# Altium schematic sheet 200PinConnector.SchDoc
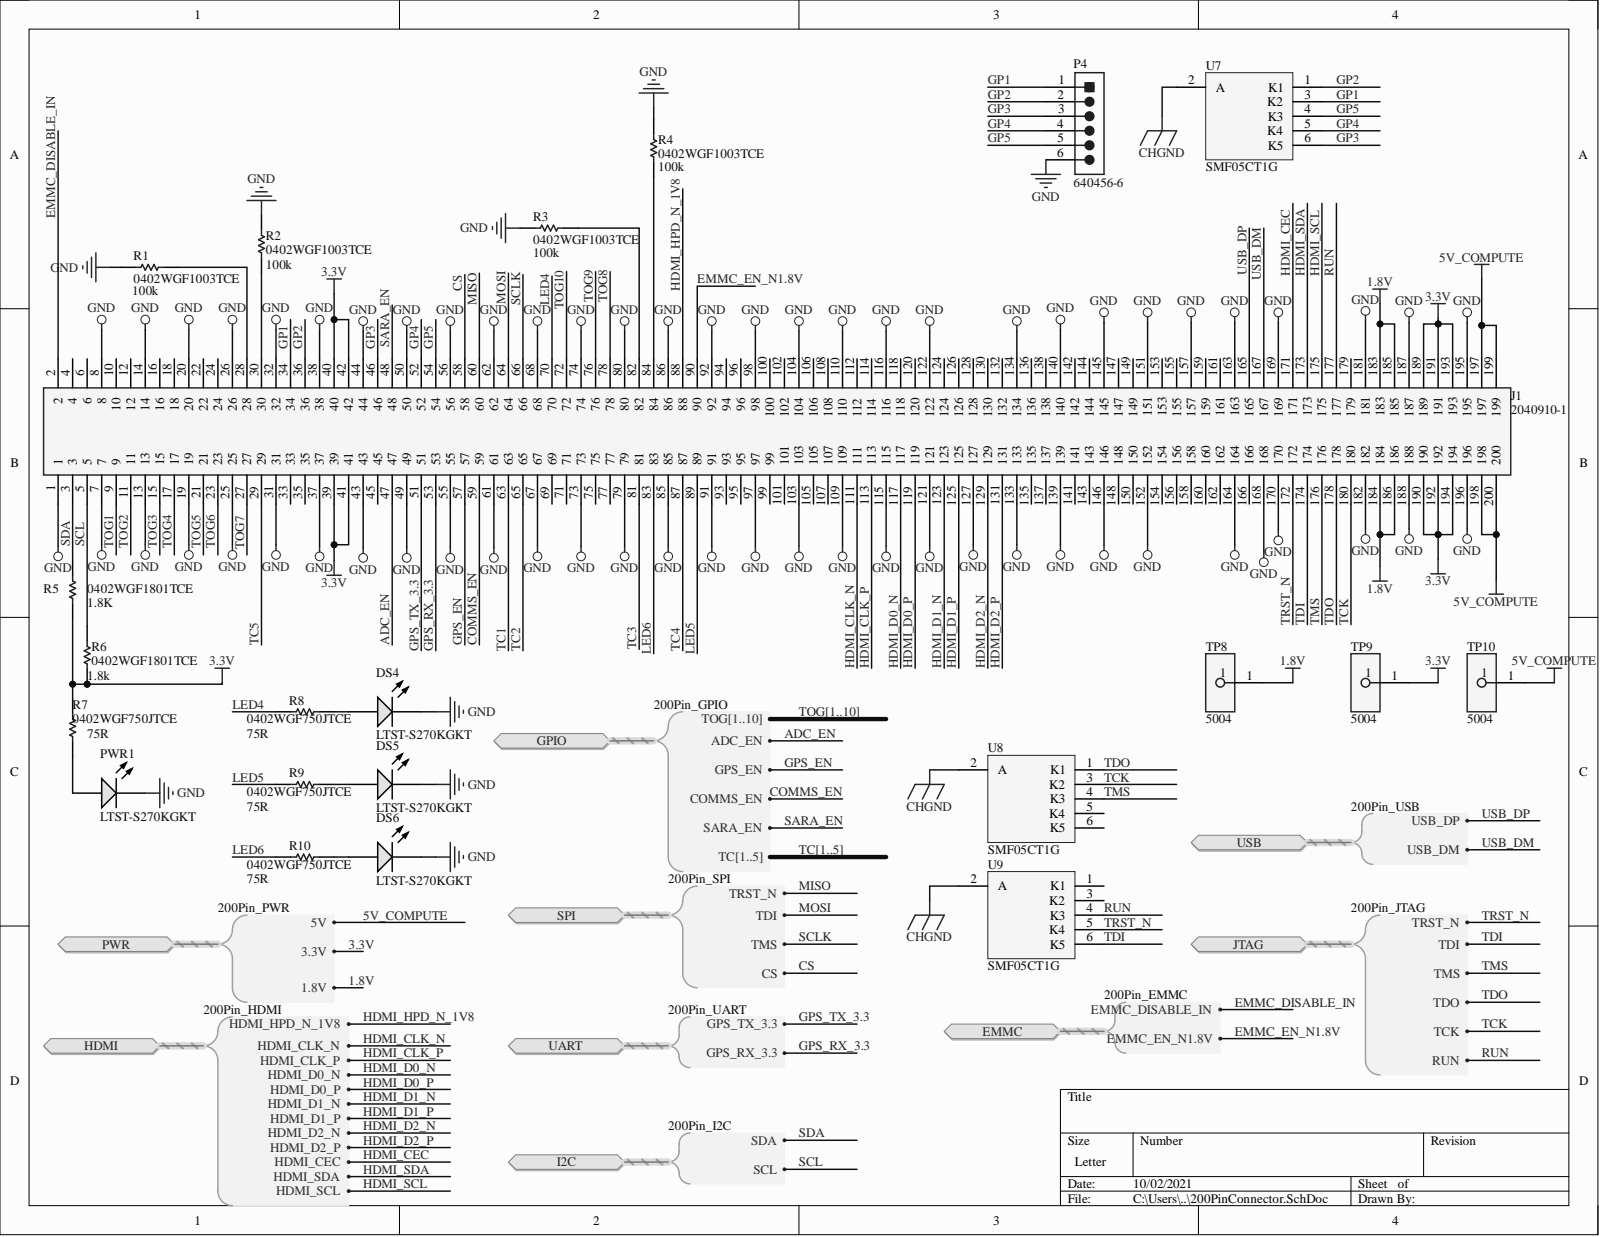
[diagram p0: 200PinConnector.SchDoc]

## Parts
DS4  LTST-S270KGKT  [AVAG-HSMX-C190_V]
DS5  LTST-S270KGKT  [AVAG-HSMX-C190_V]
DS6  LTST-S270KGKT  [AVAG-HSMX-C190_V]
J1  2040910-1  [20409101]
P4  640456-6  [TECO-640456-6_V]
PWR1  LTST-S270KGKT  [AVAG-HSMX-C190_V]
R1  0402WGF1003TCE  [0402]
R2  0402WGF1003TCE  [0402]
R3  0402WGF1003TCE  [0402]
R4  0402WGF1003TCE  [0402]
R5  0402WGF1801TCE  value 1.8K  [0402]
R6  0402WGF1801TCE  [0402]
R7  0402WGF750JTCE  [RESC1005X40X25ML05T10]
R8  0402WGF750JTCE  [RESC1005X40X25ML05T10]
R9  0402WGF750JTCE  [RESC1005X40X25ML05T10]
R10  0402WGF750JTCE  [RESC1005X40X25ML05T10]
TP8  5004  [FP-5004-MFG]
TP9  5004  [FP-5004-MFG]
TP10  5004  [FP-5004-MFG]
U7  SMF05CT1G  [ONSC-SC70-6-419B-02_W_V]
U8  SMF05CT1G  [ONSC-SC70-6-419B-02_W_V]
U9  SMF05CT1G  [ONSC-SC70-6-419B-02_W_V]

## Ports
EMMC, EMMC.EMMC_DISABLE_IN, EMMC.EMMC_EN_N1.8V, GPIO, GPIO.ADC_EN, GPIO.COMMS_EN, GPIO.GPS_EN, GPIO.SARA_EN, GPIO.TC1, GPIO.TC2, GPIO.TC3, GPIO.TC4, GPIO.TC5, GPIO.TC[1..5], GPIO.TOG1, GPIO.TOG2, GPIO.TOG3, GPIO.TOG4, GPIO.TOG5, GPIO.TOG6, GPIO.TOG7, GPIO.TOG8, GPIO.TOG9, GPIO.TOG10, GPIO.TOG[1..10], HDMI, HDMI.HDMI_CEC, HDMI.HDMI_CLK_N, HDMI.HDMI_CLK_P, HDMI.HDMI_D0_N, HDMI.HDMI_D0_P, HDMI.HDMI_D1_N, HDMI.HDMI_D1_P, HDMI.HDMI_D2_N, HDMI.HDMI_D2_P, HDMI.HDMI_HPD_N_1V8, HDMI.HDMI_SCL, HDMI.HDMI_SDA, I2C, I2C.SCL, I2C.SDA, JTAG, JTAG.RUN, JTAG.TCK, JTAG.TDI, JTAG.TDO, JTAG.TMS, JTAG.TRST_N, PWR, PWR.1.8V, PWR.3.3V, PWR.5V, SPI, SPI.CS, SPI.TDI, SPI.TMS, SPI.TRST_N, UART, UART.GPS_RX_3.3, UART.GPS_TX_3.3, USB, USB.USB_DM, USB.USB_DP

## Nets
CHGND: U7.2(A) U8.2(A) U9.2(A)
GND: DS4.2 DS5.2 DS6.2 J1.1 J1.7 J1.8 J1.13 J1.14 J1.19 J1.20 J1.25 J1.26 J1.31 J1.32 J1.37 J1.38 J1.43 J1.44 J1.49 J1.50 J1.55 J1.56 J1.61 J1.62 J1.67 J1.68 J1.73 J1.74 J1.79 J1.80 J1.85 J1.86 J1.91 J1.92 J1.97 J1.98 J1.103 J1.104 J1.109 J1.110 J1.115 J1.116 J1.121 J1.122 J1.127 J1.133 J1.134 J1.139 J1.140 J1.145 J1.146 J1.151 J1.152 J1.157 J1.163 J1.164 J1.168 J1.169 J1.170 J1.181 J1.182 J1.187 J1.188 J1.195 J1.196 P4.6 PWR1.2 R1.2 R2.2 R3.2 R4.2
1.8V: J1.183 J1.184 J1.185 J1.186 TP8.1 ; port PWR
3.3V: J1.39 J1.40 J1.41 J1.42 J1.189 J1.190 J1.191 J1.192 J1.193 J1.194 R5.1 R6.1 R7.1 TP9.1 ; port PWR
5V_COMPUTE: J1.197 J1.198 J1.199 J1.200 TP10.1 ; port PWR
ADC_EN: J1.47 ; port GPIO
COMMS_EN: J1.59 ; port GPIO
CS: J1.58 ; port SPI
EMMC_DISABLE_IN: J1.2 ; port EMMC
EMMC_EN_N1.8V: J1.90 ; port EMMC
GP1: J1.34 P4.1 U7.3(K2)
GP2: J1.36 P4.2 U7.1(K1)
GP3: J1.46 P4.3 U7.6(K5)
GP4: J1.52 P4.4 U7.5(K4)
GP5: J1.54 P4.5 U7.4(K3)
GPS_EN: J1.57 ; port GPIO
GPS_RX_3.3: J1.53 ; port UART
GPS_TX_3.3: J1.51 ; port UART
HDMI_CEC: J1.171 ; port HDMI
HDMI_CLK_N: J1.111 ; port HDMI
HDMI_CLK_P: J1.113 ; port HDMI
HDMI_D0_N: J1.117 ; port HDMI
HDMI_D0_P: J1.119 ; port HDMI
HDMI_D1_N: J1.123 ; port HDMI
HDMI_D1_P: J1.125 ; port HDMI
HDMI_D2_N: J1.129 ; port HDMI
HDMI_D2_P: J1.131 ; port HDMI
HDMI_HPD_N_1V8: J1.88 ; port HDMI
HDMI_SCL: J1.175 ; port HDMI
HDMI_SDA: J1.173 ; port HDMI
LED4: J1.70 R8.2
LED5: J1.89 R9.2
LED6: J1.83 R10.2
MISO: J1.60 ; port SPI
MOSI: J1.64 ; port SPI
RUN: J1.177 U9.4(K3) ; port JTAG
SARA_EN: J1.48 ; port GPIO
SCL: J1.5 R6.2 ; port I2C
SCLK: J1.66 ; port SPI
SDA: J1.3 R5.2 ; port I2C
TC1: J1.63 ; port GPIO  [also labelled TC]
TC2: J1.65 ; port GPIO  [also labelled TC]
TC3: J1.81 ; port GPIO  [also labelled TC]
TC4: J1.87 ; port GPIO  [also labelled TC]
TC5: J1.29 ; port GPIO  [also labelled TC]
TCK: J1.180 U8.3(K2) ; port JTAG
TDI: J1.174 U9.6(K5) ; port JTAG
TDO: J1.178 U8.1(K1) ; port JTAG
TMS: J1.176 U8.4(K3) ; port JTAG
TOG1: J1.9 ; port GPIO  [also labelled TOG]
TOG2: J1.11 ; port GPIO  [also labelled TOG]
TOG3: J1.15 ; port GPIO  [also labelled TOG]
TOG4: J1.17 ; port GPIO  [also labelled TOG]
TOG5: J1.21 ; port GPIO  [also labelled TOG]
TOG6: J1.23 ; port GPIO  [also labelled TOG]
TOG7: J1.27 ; port GPIO  [also labelled TOG]
TOG8: J1.78 ; port GPIO  [also labelled TOG]
TOG9: J1.76 ; port GPIO  [also labelled TOG]
TOG10: J1.72 ; port GPIO  [also labelled TOG]
TRST_N: J1.172 U9.5(K4) ; port JTAG
USB_DM: J1.167 ; port USB
USB_DP: J1.165 ; port USB
NetDS4_1: DS4.1 R8.1
NetDS5_1: DS5.1 R9.1
NetDS6_1: DS6.1 R10.1
NetJ1_28: J1.28 R1.1
NetJ1_30: J1.30 R2.1
NetJ1_82: J1.82 R3.1
NetJ1_84: J1.84 R4.1
NetPWR1_1: PWR1.1 R7.2

Not connected: J1 4 6 10 12 16 18 22 24 33 35 45 69 71 75 77 93 94 95 96 99 100 101 102 105 106 107 108 112 114 118 120 124 126 128 130 132 135 136 137 138 141 142 143 144 147 148 149 150 153 154 155 156 158 159 160 161 162 166 179; U8 5(K4) 6(K5); U9 1(K1) 3(K2)

## Library data of the parts
DS4-DS6 PWR1 = CMP-1744-00003-1: LED Uni-Color Yellow, 65 mW, 20 mA, -40 to 85 degC, 2-Pin SMD, RoHS, Tape and Reel; Case\Package: 0603; Power Rating: 100 mW; Resistance: 390 Ohm; Tolerance: 1%; Voltage Rating: 50 V; Case Code (Imperial): 0603; Case Code (Metric): 1608; Component Kind: Standard; ComponentLink1Description: Manufacturer URL; ComponentLink1URL: http://www.avagotech.com/; ComponentLink2Description: Datasheet; ComponentLink2URL: http://docs.avagotech.com/docs/AV02-0551EN; ComponentLink3Description: Package Specification; ComponentLink3URL: http://www.fairchildsemi.com/dwg/MA/MA03D.pdf; DatasheetVersion: Mar-12; Height: 0.45 mm; Length: 1.6 mm; Library Name: Altium Content Vault; Max Operating Temperature: 155 degC; Min Operating Temperature: -55 degC; Mount: Surface Mount; Package Quantity: 5000; PackageDescription: 2-Pin Surface Mount Device, Body 1.6 x 0.8 mm, Height 0.9 mm; PackageReference: HSMX-C190; Packaging: Tape and Reel; Pins: 2; REACH SVHC: No SVHC; RoHSCompliant: true; Voltage Rating (DC): 50 V; Width: 0.8 mm
J1 = 2040910-1: 200 Pin Connector; Manufacturer_Name: TE Connectivity; Arrow Part Number: 2040910-1; Arrow Price/Stock: https://www.arrow.com/en/products/2040910-1/te-connectivity; Component Kind: Standard; Datasheet Link: https://www.arrow.com/en/products/2040910-1/te-connectivity; Height: 15mm; Manufacturer_Part_Number: 2040910-1; Mouser Part Number: 571-2040910-1; Mouser Price/Stock: https://www.mouser.co.uk/ProductDetail/TE-Connectivity/2040910-1?qs=kAqyOuzYGe9tF8FZbDgYRA%3D%3D
P4 = CMP-2000-05661-1: Male Header, Pitch 2.54 mm, 1 x 6 Position, Height 10.03 mm, Tail Length 3.56 mm, -55 to 105 degC, Bulk; Manufacturer: TE Connectivity; Agency Approvals: CSA,UL; Angle: Straight; Brand/Series: MTA-100Series; Color, Housing: White; Connector Type: MTA-100; Contact Centerline Spacing: 0.100    in.; Contact Termination Area Plating: Tin; Current, Rating: 5    A; Material, Contact: CopperAlloy; Material, Housing: Polyester; Mount Angle: Vertical; Mounting Hole Size: 0.04    "; Number of Contacts: 6; Pin Spacing: 0.1    "; Primary Type: InterconnectSystem; Special Features: Pinstrip; Standards: RoHSCompliant,ELVCompliant,UL94V-0; Temperature, Operating: -55to+105    °C; Voltage, Rating: 250    V; Color: White; Component Kind: Standard; Contact Mating Area Plating: Tin; Contact Plating: Tin; Contact Shape: Square; Datasheet URL: https://datasheet.ciiva.com/25618/0900766b80eb7fdd-25618163.pdf; Datasheet Version: Rev. W, 05/2005; Flammability Rating: UL94V-0; Length, Overall: 0.6    "; Length, Pin: 0.14    "; Length, Terminal Post: 0.14    in.; Library Name: Altium Content Vault; Manufacturer URL: http://www.te.com/; Mounting Technology: ThroughHole; Mounting Type: PCMount; Number of Positions: 6; Number of Rows: 1; Package Description: Male Header, Pitch 2.54 mm, 1 x 6 Position, Height 10.03 mm, Tail Length 3.56 mm; Package Reference: 640456-6; Size, Post: 0.025    in.; Termination: Solder; Type: PCBPlug
R1-R4 = Res1: 100k 0402; Component Kind: Standard; LatestRevisionDate: 17-Jul-2002; LatestRevisionNote: Re-released for DXP Platform.; Library Name: Miscellaneous Devices.IntLib; PackageDescription: Axial Device, Thru-Hole; 2 Leads; 0.3 in Pin Spacing; PackageReference: AXIAL-0.3; Published: 8-Jun-2000; Signal Integrity: Res; Simulation: RESISTOR; Publisher: Altium Limited
  R1: Case Code (Imperial): 0402
R5 R6 = Res1: 1.8k 0402; Component Kind: Standard; LatestRevisionDate: 17-Jul-2002; LatestRevisionNote: Re-released for DXP Platform.; Library Name: Miscellaneous Devices.IntLib; PackageDescription: Axial Device, Thru-Hole; 2 Leads; 0.3 in Pin Spacing; PackageReference: AXIAL-0.3; Published: 8-Jun-2000; Signal Integrity: Res; Simulation: RESISTOR; Publisher: Altium Limited
R7-R10 = CMP-2002-07344-1: 75R 0402; Manufacturer: Yageo / Phycomp; Part Number: RC0402FR-07100RL; Component Kind: Standard; Library Name: Altium Content Vault
TP8-TP10 = CMP-2000-05575-2: PC TEST POINT MINIATURE YELLOW; Manufacturer: Keystone; Is Female: yes; Number Of Contacts: 1; Automotive: No; Category: Conn; Component Kind: Standard; ComponentLink2Description: Datasheet; ComponentLink2URL: https://www.keyelco.com/product-pdf.cfm?p=1313; Contact Material: Silver; Device Class L1: Connectors; Device Class L2: unset; Device Class L3: unset; Digikey Description: PC TEST POINT MINIATURE YELLOW; Height: 4.72mm; Lead Free: Yes; Library Name: Altium Content Vault; Manufacturer Part Number: 5004; Mouser Description: TEST POINT PC MINI .040"D YELLOW; Octopart: https://octopart.com/5004-keystone-28591; Package: 5004; RoHS: TRUE
U7-U9 = CMP-1061-00155-1: 5-Line Transient Voltage Suppressor Array, 6-Pin SC70, Pb-Free, Tape and Reel; Manufacturer: On Semiconductor; Direction: Unidirectional; IR Max (uA): 5; PPK Max (W): 100; Component Kind: Standard; ComponentLink1Description: Manufacturer URL; ComponentLink1URL: http://www.onsemi.com/; ComponentLink2Description: Datasheet; ComponentLink2URL: http://www.onsemi.com/pub_link/Collateral/SMF05C-D.PDF; ComponentLink3Description: Package Specification; ComponentLink3URL: http://www.onsemi.com/pub_link/Collateral/SMF05C-D.PDF; DatasheetVersion: Rev. 4; Library Name: Altium Content Vault; Mounting Technology: Surface Mount; PackageDescription: 6-Pin Small Outline Transistor, Body 2 x 1.25 mm, Pitch 0.65 mm; PackageReference: SC70-6-419B-02_W; PackageVersion: Rev. W; Packing: Tape and Reel; PartNumber: SMF05CT1G; RoHS: Pb-Free
